# Altium schematic sheet DUT-PowerSupplyMB-Rev2b.SchDoc(DUT-PowerSupplyMB-Rev2b) (continued)
## Library data of the parts (continued)
R136 = Res3: RES 499 OHM 1% 1/4W 0805; Manufacturer: KOA Speer; Manufacturer Part Number: RK73H2ATTD4990F; Power Rating: 125mW; Resistance: 499R; Temperature Coefficient: 100ppm/°C; Voltage Rating: 150V; Case Code (Imperial): 0805; Case Code (Metric): 2012; Case/Package: 0805; Component Kind: Standard; Construction: Rectangular; Depth: 1.25mm; Height: 0.5mm; Lead Free: Lead Free; Length: 2mm; Library Name: Miscellaneous Devices.IntLib; Max Operating Temperature: 155°C; Military Standard: Not; Min Operating Temperature: -55°C; Mount: Surface Mount; Number of Pins: 2; Package Quantity: 5000; Packaging: Tape and Reel; REACH SVHC: Unknown; Resistance Tolerance: 1%; Resistor Type: Thick Film; RoHS Compliant: Yes; Series: RK73H; Signal Integrity: Res; Simulation: RESISTOR; Supplier: Digi-Key; Supplier Part Number: 2019-RK73H2ATTD4990FDKR-ND; Technology: THICK FILM; Termination: SMD/SMT; Tolerance: 1%; Value: 499; Voltage Rating (DC): 150V; Width: 1.25mm
R146 = Res3: RES 20K OHM 1% 1/4W 0805; Manufacturer: KOA Speer; Manufacturer Part Number: RK73H2ATTD2002F; Power Rating: 125mW; Resistance: 20kR; Temperature Coefficient: 100ppm/°C; Voltage Rating: 150V; Case Code (Imperial): 0805; Case Code (Metric): 2012; Case/Package: 0805; Component Kind: Standard; Construction: Rectangular; Depth: 1.25mm; Height: 0.5mm; Lead Free: Lead Free; Length: 2mm; Library Name: Miscellaneous Devices.IntLib; Max Operating Temperature: 155°C; Military Standard: Not; Min Operating Temperature: -55°C; Package Quantity: 5000; Packaging: Tape and Reel; REACH SVHC: Unknown; Resistance Tolerance: 1%; Resistor Type: Thick Film; RoHS Compliant: Yes; Series: RK73H; Signal Integrity: Res; Simulation: RESISTOR; Supplier: Digi-Key; Supplier Part Number: 2019-RK73H2ATTD2002FDKR-ND; Termination: SMD/SMT; Tolerance: 1%; Value: 20K; Voltage Rating (DC): 150V; Width: 1.25mm
R153 = Res3: RES 100 OHM 1% 1/4W 1206; Manufacturer: KOA Speer; Manufacturer Part Number: RK73H2ATTD1000F; Power Rating: 125mW; Resistance: 100R; Temperature Coefficient: 100ppm/°C; Voltage Rating: 150V; Case Code (Imperial): 0805; Case Code (Metric): 2012; Case/Package: 0805; Component Kind: Standard; Construction: Rectangular; Depth: 1.25mm; Height: 0.5mm; Lead Free: Lead Free; Length: 2mm; Library Name: Miscellaneous Devices.IntLib; Max Operating Temperature: 155°C; Military Standard: Not; Min Operating Temperature: -55°C; Mount: Surface Mount; Number of Pins: 2; Package Quantity: 5000; Packaging: Tape and Reel; REACH SVHC: Unknown; Resistance Tolerance: 1%; Resistor Type: Thick Film; RoHS Compliant: Yes; Series: RK73H; Signal Integrity: Res; Simulation: RESISTOR; Supplier: Digi-Key; Supplier Part Number: 2019-RK73H2ATTD1000FDKR-ND; Technology: THICK FILM; Termination: SMD/SMT; Tolerance: 1%; Value: 100; Voltage Rating (DC): 150V; Width: 1.25mm
TP100-TP106 = TP-Round-Yellow: TP Thru-Hole; Manufacturer: UW; Manufacturer Part Number: Gold; Component Kind: Standard; Library Name: MY_TP.SchLib; Supplier: UW; Supplier Part Num,ber: Gold; Value: TP Thru-Hole
TP107-TP109 TP112 TP113 = TP-Round-Black: TP Thru-Hole; Manufacturer: UW; Manufacturer Part Number: Gold; Component Kind: Standard; Library Name: MY_TP.SchLib; Supplier: UW; Supplier Part Num,ber: Gold; Value: TP Thru-Hole
U100 = Single Op-Amp 5pin: Single, 40-V, 5-MHz, 4-mV offset voltage, 20-V/µs, In to V+ op amp with -40°C to 125°C operation 5-SOT-23 -40 to 125; Manufacturer: Texas Instruments; Manufacturer Part Number: TL071HIDBVR; Component Kind: Standard; Library Name: My_OpAmps.SchLib; Pricing 1: 1=0.45000, 10=0.36900, 25=0.33720, 100=0.25100, 250=0.22748, 500=0.18826, 1000=0.14119 (USD); RoHS: No; Stock 1: 10511; Supplier: Digi-Key; Supplier Part Number: 296-TL071HIDBVRCT-ND
U101 = Single Op-Amp 5pin: Single, 40-V, 5-MHz, 4-mV offset voltage, 20-V/µs, In to V+ op amp with -40°C to 125°C operation 5-SOT-23 -40 to 125; Manufacturer: Texas Instruments; Manufacturer Part Number: TLV9351IDBVR; Component Kind: Standard; Library Name: My_OpAmps.SchLib; Pricing 1: 1=0.45000, 10=0.36900, 25=0.33720, 100=0.25100, 250=0.22748, 500=0.18826, 1000=0.14119 (USD); RoHS: No; Stock 1: 10511; Supplier: Digi-Key; Supplier Part Number: 296-TL071HIDBVRCT-ND; Value: TL071
